# Altium schematic sheet MSE312_SIMRACER.SchDoc
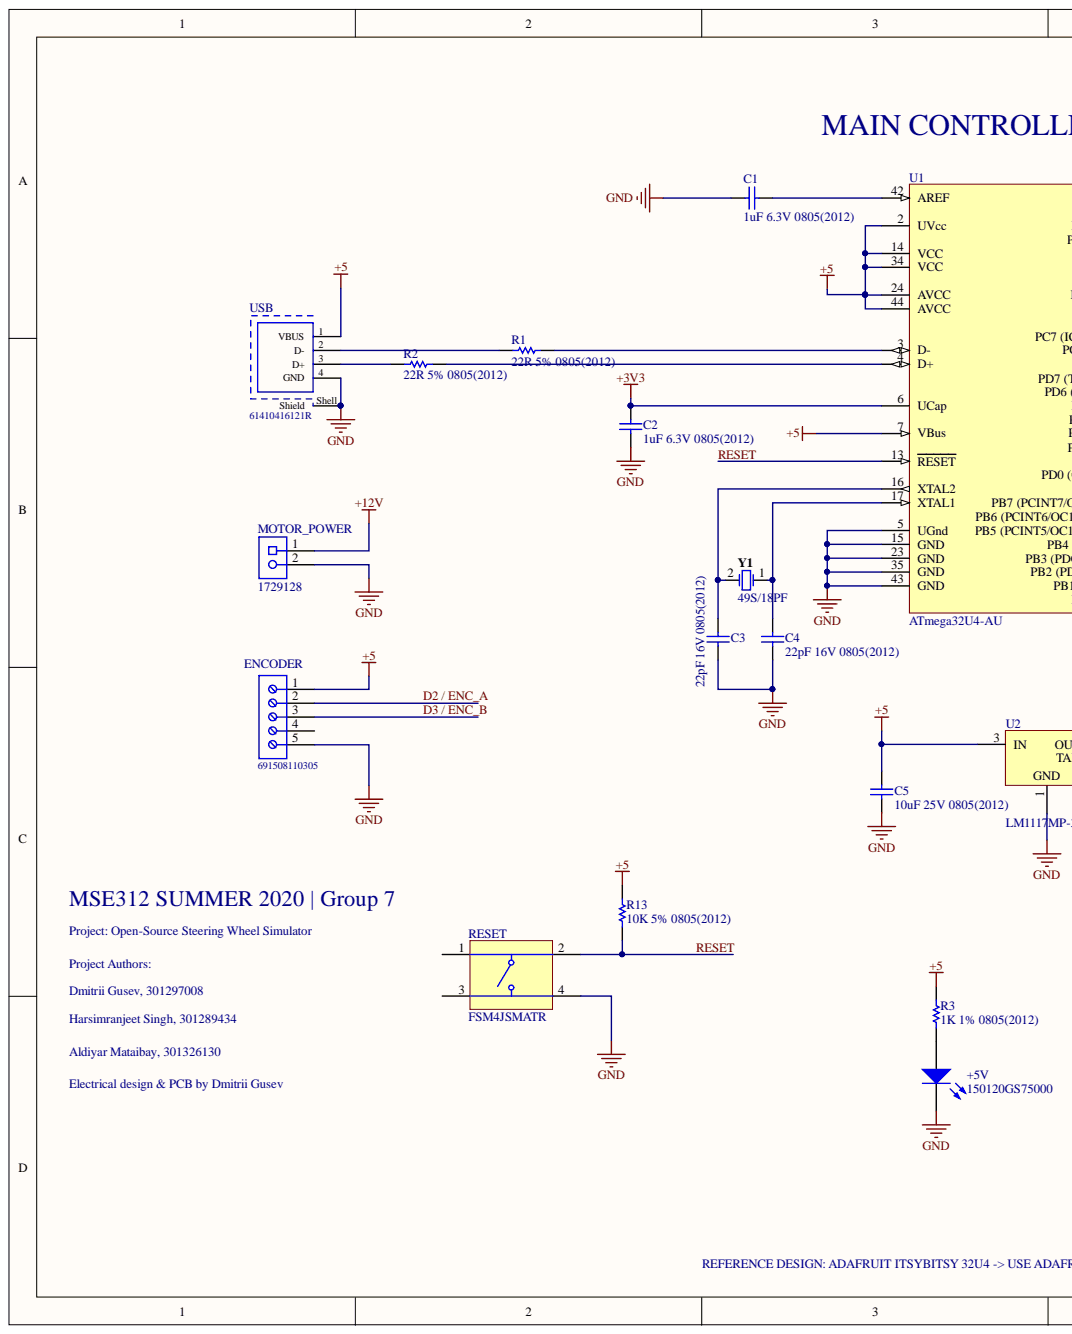
[diagram p0: MSE312_SIMRACER.SchDoc, left]
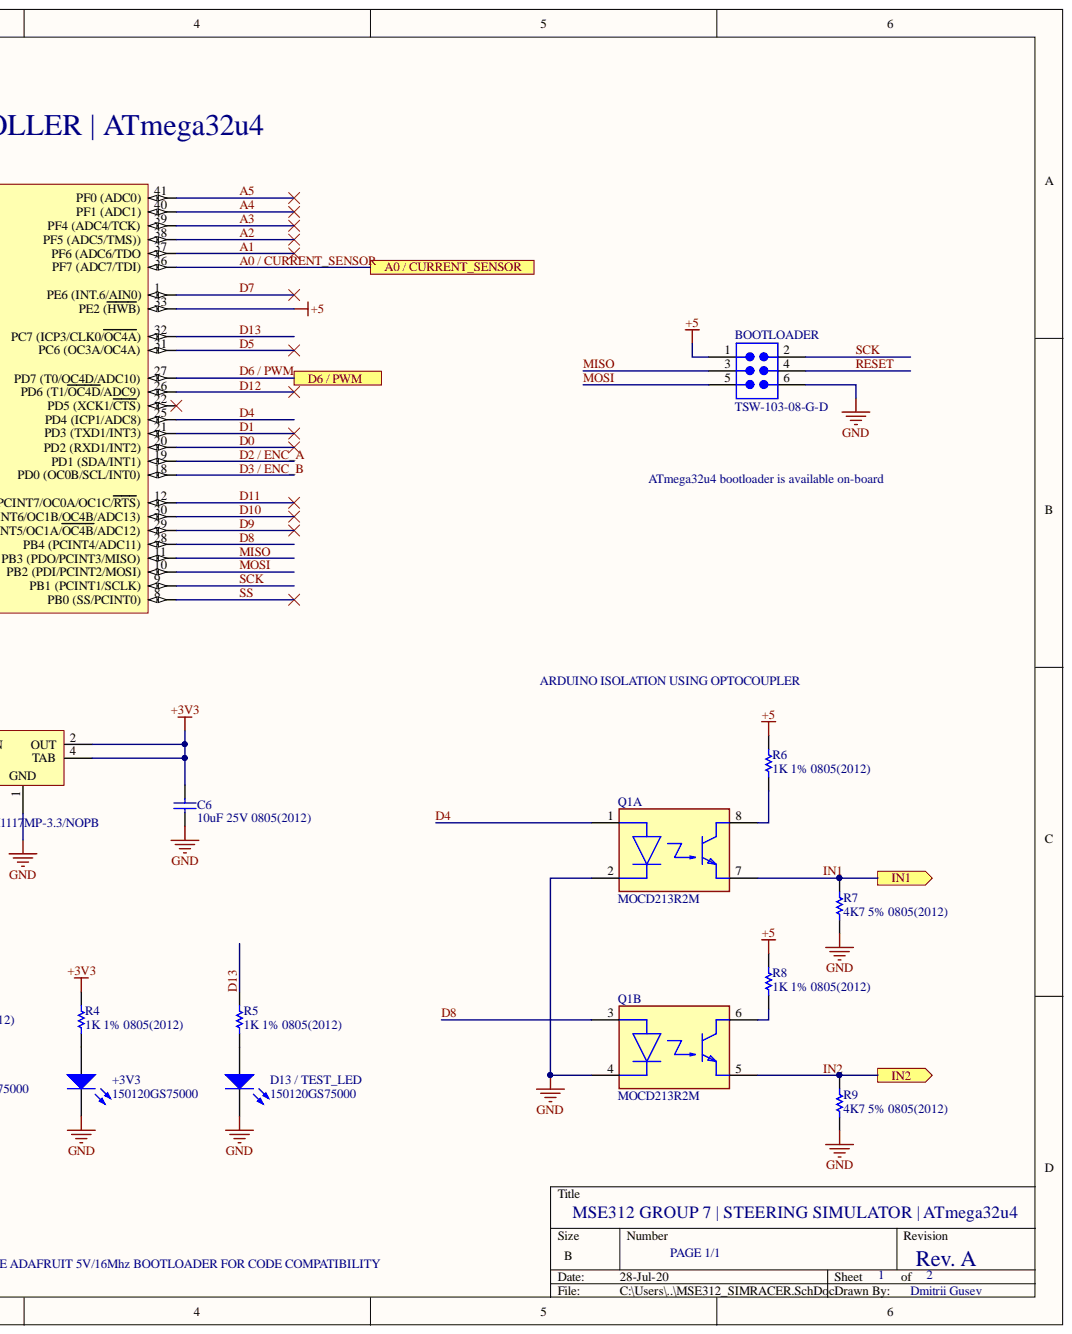
[diagram p1: MSE312_SIMRACER.SchDoc, right]

## Parts
+3V3  150120GS75000  [1206_A]
+5V  150120GS75000  [1206_A]
BOOTLOADER  TSW-103-08-G-D  [SMTC-TSW-103-08-X-D]
C1  1uF 6.3V 0805(2012)  value 1uF  [CAPC0805(2012)145_N]
C2  1uF 6.3V 0805(2012)  value 1uF  [CAPC0805(2012)145_N]
C3  22pF 16V 0805(2012)  value 22pF  [CAPC0805(2012)100_N]
C4  22pF 16V 0805(2012)  value 22pF  [CAPC0805(2012)100_N]
C5  10uF 25V 0805(2012)  value 10uF  [CAPC0805(2012)145_N]
C6  10uF 25V 0805(2012)  value 10uF  [CAPC0805(2012)145_N]
D13_/_TEST_LED  150120GS75000  [1206_A]
ENCODER  691508110305  [691508110305]
MOTOR_POWER  1729128  [PHOE-1729128-2_V]
Q1  MOCD213R2M  [FP-751DZ-MFG]  (parts A,B)
R1  22R 5% 0805(2012)  [RESC0805(2012)_M]
R2  22R 5% 0805(2012)  [RESC0805(2012)_M]
R3  1K 1% 0805(2012)  [RESC0805(2012)_M]
R4  1K 1% 0805(2012)  [RESC0805(2012)_M]
R5  1K 1% 0805(2012)  [RESC0805(2012)_M]
R6  1K 1% 0805(2012)  [RESC0805(2012)_M]
R7  4K7 5% 0805(2012)  [RESC0805(2012)_N]
R8  1K 1% 0805(2012)  [RESC0805(2012)_M]
R9  4K7 5% 0805(2012)  [RESC0805(2012)_N]
R13  10K 5% 0805(2012)  [RESC0805(2012)_L]
RESET  FSM4JSMATR  [TECO-FSM4JSMATR_V]
U1  ATmega32U4-AU  [44ML_M]
U2  LM1117MP-3.3/NOPB  [DCY0004A_L]
USB  61410416121R  [61410416121R]
Y1  49S/18PF  [JPY-49S-18PF]

## Ports
A0 / CURRENT_SENSOR, D6 / PWM, IN1, IN2

## Nets
+3V3: C2.2 C6.2 R4.2 U1.6(UCap) U2.2(OUT) U2.4(TAB)
+5: BOOTLOADER.1 C5.2 ENCODER.1 R3.2 R6.2 R8.2 R13.2 U1.2(UVcc) U1.7(VBus) U1.14(VCC) U1.24(AVCC) U1.33(PE2_(HWB)) U1.34(VCC) U1.44(AVCC) U2.3(IN) USB.1(VBUS)
+12V: MOTOR_POWER.1
GND: +3V3.1 +5V.1 BOOTLOADER.6 C1.1 C2.1 C3.1 C4.1 C5.1 C6.1 D13_/_TEST_LED.1 ENCODER.5 MOTOR_POWER.2 Q1.2 Q1.4 R7.1 R9.1 RESET.4 U1.5(UGnd) U1.15(GND) U1.23(GND) U1.35(GND) U1.43(GND) U2.1 USB.4(GND) USB.Shell(Shield)
A0 / CURRENT_SENSOR: U1.36(PF7_(ADC7/TDI)) ; port A0 / CURRENT_SENSOR
A1: U1.37(PF6_(ADC6/TDO)
A2: U1.38(PF5_(ADC5/TMS)))
A3: U1.39(PF4_(ADC4/TCK))
A4: U1.40(PF1_(ADC1))
A5: U1.41(PF0_(ADC0))
D0: U1.20(PD2_(RXD1/INT2))
D1: U1.21(PD3_(TXD1/INT3))
D2 / ENC_A: ENCODER.2 U1.19(PD1_(SDA/INT1))
D3 / ENC_B: ENCODER.3 U1.18(PD0_(OC0B/SCL/INT0))
D4: Q1.1 U1.25(PD4_(ICP1/ADC8))
D5: U1.31(PC6_(OC3A/OC4A))
D6 / PWM: U1.27(PD7_(T0/OC4D/ADC10)) ; port D6 / PWM
D7: U1.1(PE6_(INT.6/AIN0))
D8: Q1.3 U1.28(PB4_(PCINT4/ADC11))
D9: U1.29
D10: U1.30(PB6_(PCINT6/OC1B/OC4B/ADC13))
D11: U1.12
D12: U1.26(PD6_(T1/OC4D/ADC9))
D13: R5.2 U1.32(PC7_(ICP3/CLK0/OC4A))
IN1: Q1.7 R7.2 ; port IN1
IN2: Q1.5 R9.2 ; port IN2
MISO: BOOTLOADER.3 U1.11
MOSI: BOOTLOADER.5 U1.10(PB2_(PDI/PCINT2/MOSI))
RESET: BOOTLOADER.4 R13.1 RESET.2 U1.13(RESET)
SCK: BOOTLOADER.2 U1.9(PB1_(PCINT1/SCLK))
SS: U1.8(PB0_(SS/PCINT0))
Net+3V3_2: +3V3.2 R4.1
Net+5V_2: +5V.2 R3.1
NetC1_2: C1.2 U1.42(AREF)
NetC3_2: C3.2 U1.16(XTAL2) Y1.2
NetC4_2: C4.2 U1.17(XTAL1) Y1.1
NetD13 / TEST_LED_2: D13_/_TEST_LED.2 R5.1
NetQ1_6: Q1.6 R8.1
NetQ1_8: Q1.8 R6.1
NetR1_1: R1.1 USB.2(D-)
NetR1_2: R1.2 U1.3(D-)
NetR2_1: R2.1 USB.3(D+)
NetR2_2: R2.2 U1.4(D+)

Not connected: ENCODER 4; RESET 1 3; U1 22(PD5_(XCK1/CTS))

## Library data of the parts
+3V3 +5V D13_/_TEST_LED = CMP-1426-00014-1: SMD mono-color Chip LED, WL-SMCW, Green; Manufacturer: Wuerth Elektronik; Color: Green; Component Kind: Standard; ComponentLink1Description: Manufacturer URL; ComponentLink1URL: http://www.we-online.com; ComponentLink2Description: Datasheet; ComponentLink2URL: http://katalog.we-online.com/led/datasheet/150120GS75000.pdf; Library Name: Altium Content Vault; PackageReference: 1206_A; PartNumber: 150120GS75000
BOOTLOADER = CMP-1024-00338-1: Manufacturer: Samtec; Pitch: 2.54 mm; Component Kind: Standard; ComponentLink1Description: Manufacturer URL; ComponentLink1URL: http://www.samtec.com; ComponentLink2Description: Datasheet; ComponentLink2URL: http://www.samtec.com/documents/webfiles/pdf/TSW_TH.PDF; ComponentLink3Description: Package Specification; ComponentLink3URL: http://www.samtec.com/documents/webfiles/cpdf/TSW-XXX-XX-XXX-X-XX-XXX-MKT.pdf; DatasheetVersion: F-214; Library Name: Altium Content Vault; Mounting Technology: Through-hole; Orientation: Vertical; PackageDescription: .025[0.64] SQ. Post Terminal Strip Assembly; PackageReference: TSW-103-08-X-D; PackageVersion: DM, 8/1988; PartNumber: TSW-103-08-G-D; RoHS: True; Temperature Range: -55 to 125 degC
C1 C2 = CMP-1036-04731-1: CAP 1uF 6.3V ±10% 0805 (2012 Metric) Thickness 1.45mm SMD; Case-EIA: 0805; Case-Metric: 2012; Tolerance: Â±10%; Component Kind: Standard; Library Name: Altium Content Vault; Max Thickness: 1.45 mm; Rated Voltage: 6.3 V; Technology: SMT
C3 C4 = CMP-1036-01215-1: CAP 22pF 16V ±0.5pF 0805 (2012 Metric) Thickness 1mm SMD; Case-EIA: 0805; Case-Metric: 2012; Tolerance: Â±0.5pF; Component Kind: Standard; Library Name: Altium Content Vault; Max Thickness: 1 mm; Rated Voltage: 16 V; Technology: SMT
C5 C6 = CMP-1036-04932-1: CAP 10uF 25V ±10% 0805 (2012 Metric) Thickness 1.45mm SMD; Case-EIA: 0805; Case-Metric: 2012; Tolerance: Â±10%; Component Kind: Standard; Library Name: Altium Content Vault; Max Thickness: 1.45 mm; Rated Voltage: 25 V; Technology: SMT
ENCODER = CMP-1502-02493-1: Serie 5081 - 3.50 mm Horizontal Entry SMT with Rising Cage Clamp WR-TBL, 5 pin; Manufacturer: Wurth Elektronik; Pin Number: 5; Pitch: 3.50mm; Component Kind: Standard; Datasheet URL: http://katalog.we-online.de/em/datasheet/6915081103xx.pdf; Library Name: Altium Content Vault; Manufacturer URL: http://www.we-online.de; Mounting Technology: SMT; Operating Temperature: -40to130degC; Package Reference: 691508110305; Packaging: TapeandReelwithCap; Part Number: 691508110305; Publisher: WurthElektronik
MOTOR_POWER = CMP-2000-05815-1: PCB Terminal Block, 13.5 A, 400 V, 2-Pin THD, RoHS, Bulk; Manufacturer: Phoenix Contact; Current Rating: 13500mA; No. of Positions: 2; No. of Rows: 1; Package Version: 03/2015; Pitch: 5.08mm; Voltage Rating: 400V; Component Kind: Standard; Datasheet URL: https://datasheet.ciiva.com/16046/1729128-16046181.pdf; Datasheet Version: 12/2013; Library Name: Altium Content Vault; Manufacturer URL: http://www.phoenixcontact.com/; Mounting Technology: ThroughHole; Orientation: Horizontal; Package Description: 2-Pin Through Hole Device, Body 8.1 x 10.16 mm, Pitch 5.08 mm; Package Reference: 1729128-2; Package URL: https://www.phoenixcontact.com/online/portal/pi/pxc/product_detail_page/!ut/p/b1/3VdJjqNKFDxLH8AFycySyWY2ozFsEPOMMQaDOX1XedGL_1Vdm5YXJleknhSKeBkvI6EA8ncAo3AYoDhBQGco6KN7VURTdemj9us_IEKEZo_YHiPAEWEFmDGOHMORkqKYCORBZxgL7ZoaZYcbFFUZ3fqxSY6GOuxydWUuHqz1ynmMFygu583Bob0Ewr6Sd_l-5m-kAueHiB0jpRwZwe58wwtkpxtMYd_LmGcDRU02dRNEyWt6Y2ti5pSyJ_reIkzIulrqApdnbT11V5jnCKbYNCvhEM1MCpPByat_X379-iThf08CI5GfOPqfBeS3BRoBOdD55AKVv0iLwCMq-2BrzXWSGRH7pUGD9uwXQjiph3xL0DKQwdGa0JImiIOmBNOestqgwTHSSs2oOep-78apyXQBvNi8ZLaFjvjWvcaUEBu0MC6Wbe-d6mB0FAebo2lUWinqYaZs5DAYzV0RP9yitUjnGJ0iI9xhZkT42OCQNUJJhkgWINNQLP2JFP6OpMg3JCVg70jqHT0lvKOnhHf0lPuOnnLf0VPuWx6_dxwUIvwk5WMkT0kLL1mh61l2yZVSzG3pYV_bqo-mj6uMKwKwdnnW8Jh5RTOJzMOA5c-eJDaZQw4mTMPH02PvS_ZAqNVjVTo2WyYLkwH_KU1mmmG5kjRhDqPXLwwVHvVOjCM60-fpXvTDfMGW1PVFVS1r4e4yVDk4ZdNbkUHvqPqQSeian4UD39fNKmachqdJ1NJbyk8rXT9mXTvPk3oE5meulKGgiruPJek-4I9hTT6G8ZLOyRSm2RRVbThERQad5OS28hdt4YW4vG0stgYYzsWsK4_7y6DZMjJSCqri1kyiZR5vD7pwIrNR7QwPHTcLTI5qT7eRlpHrdGiupYlqQDJ7zy_CND8fWWBoLRXxk1RVcXiBQwbTD4Xi7KL-FuO94t9xaqweXzH; Packing: Bulk; PartNumber: 1729128; RoHS: TRUE
Q1 = CMP-07144-000004-1: OPTOISO 2.5KV 2CH TRANS 8SOIC; Manufacturer: ON Semiconductor; Collector Emitter Voltage: 70V; Emiiter Collector Voltage: 7V; Forward Current: 10mA; Forward Voltage: 1.15V; Isolation Voltage: 2500Vrms; Max Junction Temp: +125°C; Min Current Transfer Ratio: 100%; Power Dissipation: 240mW; Reverse Voltage: 6V; Standoff Height: 0.08mm; Turn Off Delay Time: 5.7us; Turn On Delay Time: 7.5us; Ambient Temperature Range High: +100°C; Ambient Temperature Range Low: -40°C; Automotive: No; Category: Trans; Component Kind: Standard; ComponentLink2Description: Datasheet; ComponentLink2URL: https://www.onsemi.com/pub/Collateral/MOCD217M-D.PDF; Device Class L1: Optoelectronics; Device Class L2: Optocouplers; Device Class L3: unset; Digikey Description: OPTOISO 2.5KV 2CH TRANS 8SOIC; Footprint Url: https://www.onsemi.com/pub/Collateral/751DZ.PDF; Height: 3.63mm; Lead Free: Yes; Library Name: Altium Content Vault; Manufacturer Part Number: MOCD213R2M; Mouser Description: Optocoupler DC-IN 2-Channel Transistor DC-Output 8-Pin SOIC N T/R; Octopart: https://octopart.com/mocd213r2m-on+semiconductor-84330505; Package: 751DZ; PartId: 1; RoHS: TRUE; Temperature: -40°C to +100°C; Temperature Range High: +100°C; Temperature Range Low: -40°C
R1 R2 = CMP-1013-00034-1: 22R 0.125W 5% 0805 (2012 Metric)  SMD; Case-EIA: 0805; Case-Metric: 2012; Value: 22.00 Ohm; Component Kind: Standard; Library Name: Altium Content Vault; Power: 0.125 W; Technology: SMT; Tolerance: 5 %
R3-R6 R8 = CMP-1013-00510-1: 1K 0.125W 1% 0805 (2012 Metric)  SMD; Case-EIA: 0805; Case-Metric: 2012; Value: 1000.00 Ohm; Component Kind: Standard; Library Name: Altium Content Vault; Power: 0.125 W; Technology: SMT; Tolerance: 1 %
R7 R9 = CMP-1013-00090-1: 4K7 0.125W 5% 0805 (2012 Metric)  SMD; Case-EIA: 0805; Case-Metric: 2012; Value: 4700.00 Ohm; Component Kind: Standard; Library Name: Altium Content Vault; Power: 0.125 W; Technology: SMT; Tolerance: 5 %
R13 = CMP-1013-00098-1: 10K 0.125W 5% 0805 (2012 Metric)  SMD; Case-EIA: 0805; Case-Metric: 2012; Value: 10000.00 Ohm; Component Kind: Standard; Library Name: Altium Content Vault; Power: 0.125 W; Technology: SMT; Tolerance: 5 %
RESET = CMP-2000-07486-1: Micro Miniature Push Button Switch FSM JSM, 50 mA, -35 to 85 degC, 4-Pin SMD, RoHS, Tape and Reel; Manufacturer: TE Connectivity; Actuator Length: 0.055inch; Actuator Material: Polyamide; Capacitance: 0f; Case\/Package: SMD/SMT; Contact Current Rating: 50mA; Contact Resistance: 100mOhm; Electrical Life: 100000Cycles; Illumination Type: Illuminated; Max Voltage Rating (DC): 12V; Operating Force: 160gf; Switch Travel: 0.25mm; Throw Configuration: SPST; Voltage Rating (DC): 24V; Component Kind: Standard; Contact Material: CopperAlloy; Contact Plating: Silver; Current Rating: 50mA; Datasheet URL: http://www.te.com/commerce/DocumentDelivery/DDEController?Action=showdoc&DocId=Customer+Drawing%7F2-1437565-7%7FV%7Fpdf%7FEnglish%7FENG_CD_2-1437565-7_V.pdf%7F4-1437565-2; Datasheet Version: Rev. V, 02/2015; Library Name: Altium Content Vault; Manufacturer URL: http://www.te.com/; Max Operating Temperature: 85degC; Mechanical Life: 100000Cycles; Min Operating Temperature: -35degC; Mount: SurfaceMount; Mounting Technology: SurfaceMount; Orientation: Straight; Package Description: 4-Pin Surface Mount Device, Body 6 x 6 mm, Pitch 4.5 mm; Package Quantity: 1200; Package Reference: FSM4JSMATR; Packaging: TapeandReel; REACH SVHC: Unknown; RoHSCompliant: true; Termination: GullWing
U1 = CMP-0095-00285-2: 8-bit AVR Microcontroller, 2.7-5.5V, 16MHz, 32KB Flash, 1KB EEPROM, 2.5KB SRAM, USB Controller, 44-pin TQFP, Industrial Grade (-40°C to 85°C), Ext Osc; Component Kind: Standard; ComponentLink1Description: Manufacturer URL; ComponentLink1URL: http://www.atmel.com/; ComponentLink2Description: ATmega16U4/32U4 Datasheet; ComponentLink2URL: http://www.atmel.com/dyn/resources/prod_documents/doc7766.pdf; ComponentLink3Description: ATmega16U4 ATmega32U4 Summary; ComponentLink3URL: http://www.atmel.com/dyn/resources/prod_documents/7766S.pdf; DatasheetVersion: revF, Nov-2010; Library Name: Altium Content Vault; PackageDescription: 44-Pin Plastic Thin Quad Flat Pack, 10 x 10 x 1.0 mm Body, 0.8 mm Pitch (TQFP); PackageReference: 44ML; PackageVersion: rev.G, Jul-2007; RevisionNote: DatasheetVersion updated. URLs added.
U2 = CMP-0062-01638-4: 800mA Low-Dropout Linear Regulator, 4-pin SOT-223, Pb-Free; Manufacturer: Texas Instruments; CompType: LDO IC; High-Reliability: Y; PackageTopMarking: XYTT*N05A; PowerWise: N; Code_IPC: SOT223-4; Code_JEDEC: TO-261-AA; Component Kind: Standard; ComponentLink1Description: Datasheet URL; ComponentLink1URL: http://www.ti.com/general/docs/lit/getliterature.tsp?genericPartNumber=LM1117-N&fileType=pdf; Library Name: Altium Content Vault; PackageDescription: Molded SOT-223, 6.50 x 3.56 x 1.6mm Body, 4 Lead, 2.3mm Pitch; PackageReference: DCY0004A; PartNumber: LM1117MP-3.3/NOPB; PinCount: 4; RoHS: Y
USB = CMP-1502-03245-1: USB 2.0 Type B Receptacle WR-COM, Horizontal, SMT; Manufacturer: Wurth Elektronik; Contact Resistance: 30mOhm; Rated Current: 1.5A; Working Voltage: 30VAC; Component Kind: Standard; Connector Type: USB2.0TypeB; Datasheet URL: https://katalog.we-online.de/em/datasheet/61410416121R.pdf; Gender: Receptacle; Library Name: Altium Content Vault; Manufacturer URL: http://www.we-online.de; Mounting Technology: SMT; Operating Temperature: -55to85degC; Orientation: Horizontal; Package Reference: 61410416121R; Packaging: TapeandReel; Part Number: 61410416121R; Publisher: WurthElektronik
Y1 = CMP-2100-03581-1: Crystal, 16 MHz, 18 pF, -20 to 70 degC, 2-Pin THD; Manufacturer: Shenzhen Jingpengyuan; Component Kind: Standard; Datasheet URL: http://datasheet.octopart.com/49S-18PF-Xinhunjing-datasheet-30677139.pdf; Datasheet Version: 41852.0; Library Name: Altium Content Vault; Manufacturer URL: http://www.chjiahui.com/; Package Description: 2-Pin Through Hole Device, Body 11.05 x 4.65 mm, Pitch 4.88 mm; Package Reference: 49S-18PF
